# Altium schematic sheet 4_Supply.SchDoc
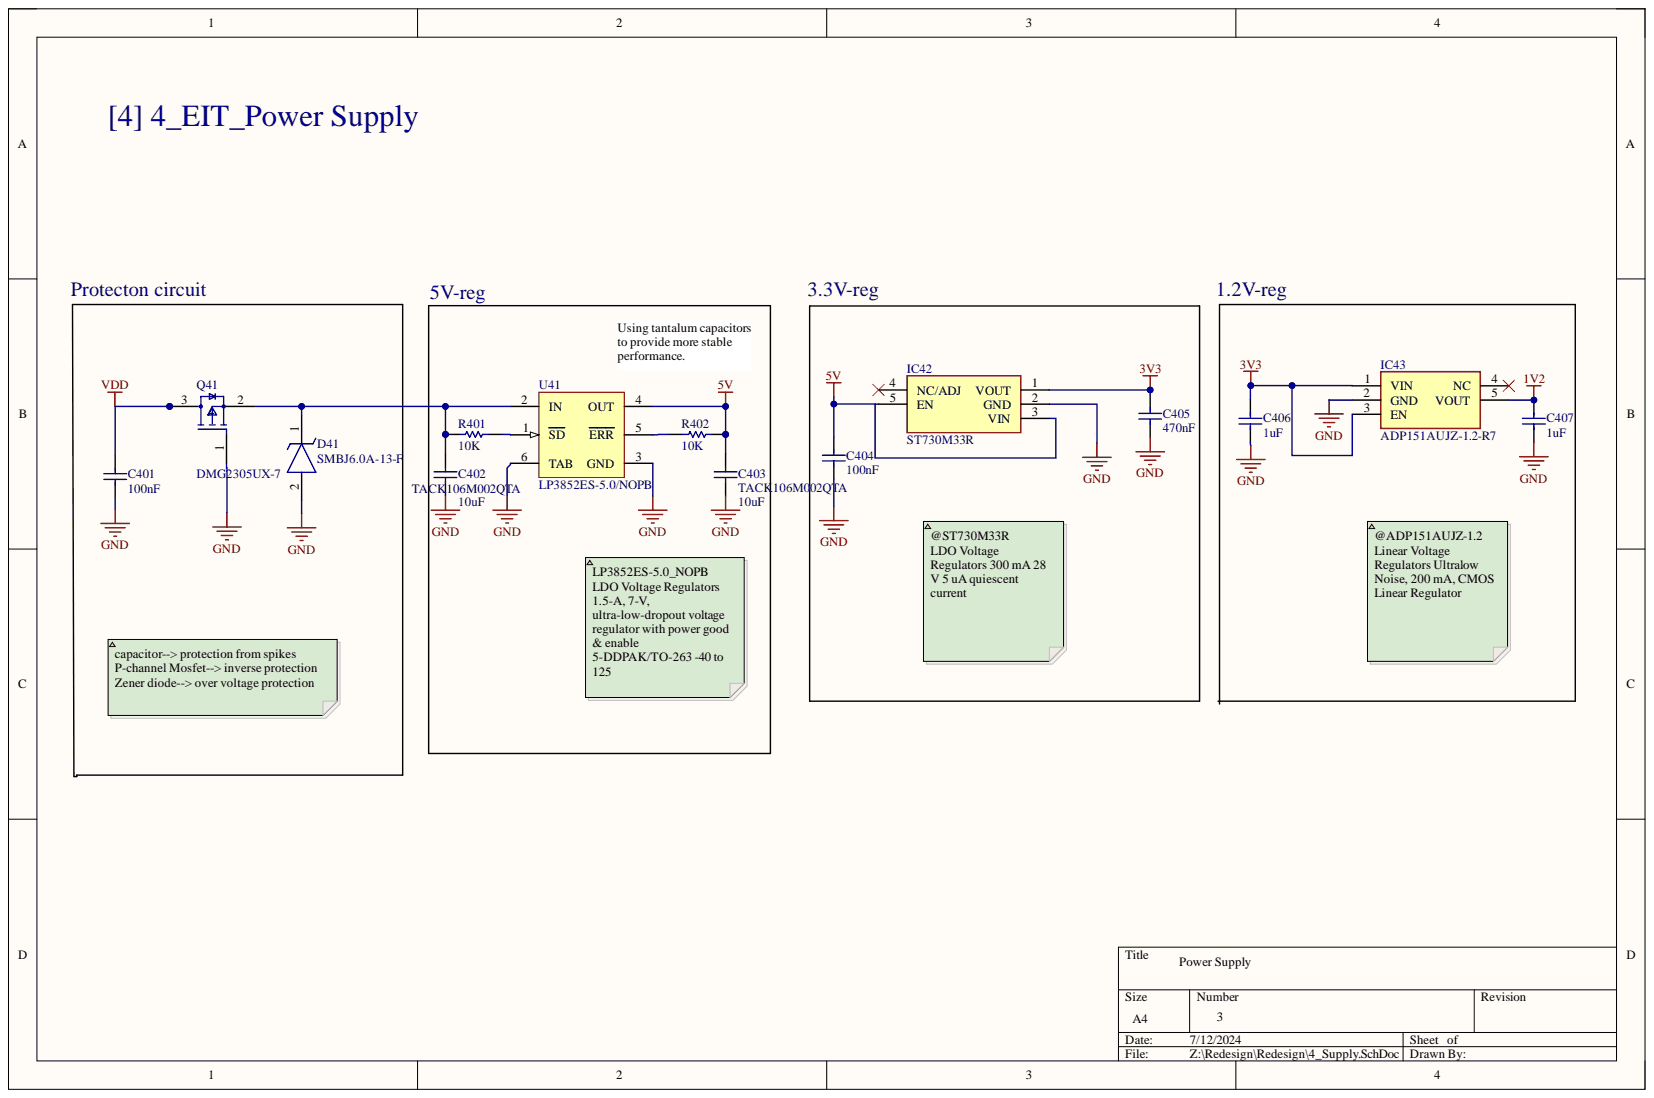
[diagram p0: 4_Supply.SchDoc]

## Parts
C401  value 100nF  [CAPC1005X55X30LL05T10]  (hidden comment: generic)
C402  TACK106M002QTA  Capacitance Const: 10uF  [CAPC1005X70X10LL20T00]
C403  TACK106M002QTA  Capacitance Const: 10uF  [CAPC1005X70X10LL20T00]
C404  value 100nF  [CAPC1005X55X30LL05T10]  (hidden comment: generic)
C405  value 470nF  [CAPC1005X55X30LL05T10]  (hidden comment: generic)
C406  value 1uF  [CAPC1005X55X30LL05T10]  (hidden comment: generic)
C407  value 1uF  [CAPC1005X55X30LL05T10]  (hidden comment: generic)
D41  SMBJ6.0A-13-F  [SMBJ12A]
IC42  ST730M33R  [SOT95P280X145-5N]
IC43  ADP151AUJZ-1.2-R7  [ADI-UJ-5_L]
Q41  DMG2305UX-7  [FP-SOT23-IPC_B]
R401  10K  [RESC1005X40X25LL10T10]
R402  10K  [RESC1005X40X25LL10T10]
U41  LP3852ES-5.0/NOPB  [KTT0005B_N]

## Nets
1V2: C407.1 IC43.5(VOUT)
3V3: C405.1 C406.1 IC42.1(VOUT) IC43.1(VIN) IC43.3(EN)
5V: C403.2 C404.1 IC42.3(VIN) IC42.5(EN) R402.1 U41.4(OUT)
GND: C401.2 C402.1 C403.1 C404.2 C405.2 C406.2 C407.2 D41.2 IC42.2(GND) IC43.2(GND) Q41.1 U41.3(GND) U41.6(TAB)
VDD: C401.1 Q41.3
NetC402_2: C402.2 D41.1 Q41.2 R401.2 U41.2(IN)
NetR401_1: R401.1 U41.1(SD)
NetR402_2: R402.2 U41.5(ERR)

Not connected: IC42 4(NC/ADJ); IC43 4(NC)

## Library data of the parts
C401 C404-C407 = Capacitor: Component Kind: Standard; Description: generic; Device Class L1: Passive Components; Device Class L2: Capacitors; Library Name: RPI_EIT_SchLib.SchLib
C402 C403 = CAP: Manufacturer: AVX Interconnect / Elco; Component Kind: Standard; Part Number: TACK106M002QTA; Library Name: RPI_EIT_SchLib.SchLib
D41 = SMBJ6.0A-13-F: TVS Diode (Uni-directional); Manufacturer_Name: Diodes Incorporated; Arrow Part Number: SMBJ6.0A-13-F; Arrow Price/Stock: https://www.arrow.com/en/products/smbj6.0a-13-f/diodes-incorporated?region=nac; Component Kind: Standard; Datasheet Link: https://www.mouser.com/datasheet/2/115/ds19002-1078141.pdf; Height: 2.5mm; Manufacturer_Part_Number: SMBJ6.0A-13-F; Mouser Part Number: 621-SMBJ6.0A-13-F; Mouser Price/Stock: https://www.mouser.co.uk/ProductDetail/Diodes-Incorporated/SMBJ60A-13-F?qs=gaDBXWSqsDAIOdP0T6ZIBg%3D%3D; Library Name: RPI_EIT_SchLib.SchLib
IC42 = ST730M33R: Integrated Circuit; Manufacturer_Name: STMicroelectronics; Arrow Part Number: ST730M33R; Arrow Price/Stock: https://www.arrow.com/en/products/st730m33r/stmicroelectronics?region=nac; Component Kind: Standard; Datasheet Link: https://www.mouser.at/datasheet/2/389/st730-1621297.pdf; Height: 1.45mm; Manufacturer_Part_Number: ST730M33R; Mouser Part Number: 511-ST730M33R; Mouser Price/Stock: https://www.mouser.co.uk/ProductDetail/STMicroelectronics/ST730M33R?qs=GedFDFLaBXHUqSNzaYM2bg%3D%3D; Library Name: RPI_EIT_SchLib.SchLib
IC43 = ADP151AUJZ-1.2-R7: Integrated Circuit; Manufacturer_Name: Analog Devices; Arrow Part Number: ADP151AUJZ-1.2-R7; Arrow Price/Stock: https://www.arrow.com/en/products/adp151aujz-1.2-r7/analog-devices?region=nac; Component Kind: Standard; Datasheet Link: https://componentsearchengine.com/Datasheets/2/ADP151AUJZ-1.2-R7.pdf; Height: 1mm; Manufacturer_Part_Number: ADP151AUJZ-1.2-R7; Mouser Part Number: 584-ADP151AUJZ-1.2R7; Mouser Price/Stock: https://www.mouser.co.uk/ProductDetail/Analog-Devices/ADP151AUJZ-1.2-R7?qs=WIvQP4zGanhSFSaaw6omsw%3D%3D; Library Name: RPI_EIT_SchLib.SchLib
Q41 = CMP-1693-00002-4: MOSFET P-CH 20V 4.2A SOT23; Drain to Source Resistance: 200mR; Element Configuration: Single; Fall Time: 34.7ns; Gate to Source Voltage (Vgs): 8V; Input Capacitance: 808pF; Max Power Dissipation: 1.4W; Number of Channels: 1; On-State Resistance: 52MR; Rise Time: 13.7ns; Threshold Voltage: -900mV; Turn-Off Delay Time: 79.3ns; Turn-On Delay Time: 10.8ns; Case/Package: SOT-23; Component Kind: Standard; Contact Plating: Tin; Continuous Drain Current (ID): 4.2A; Drain to Source Voltage (Vdss): 20V; Height: 1.1mm; Lead Free: Lead Free; Length: 3mm; Library Name: Altium Content Vault; Max Operating Temperature: 150°C; Min Operating Temperature: -55°C; Mount: Surface Mount; Number of Pins: 3; Package Quantity: 3000; Packaging: Tape and Reel; Radiation Hardening: No; Rds On Max: 52mR; REACH SVHC: No SVHC; Weight: 0.000282oz; Width: 1.4mm
R401 R402 = Resistor: generic; Manufacturer: Yageo / Phycomp; Part Number: RC0402FR-0747KL; Component Kind: Standard; Library Name: RPI_EIT_SchLib.SchLib
U41 = CMP-0062-00102-3: 1.5A Fast Ultra Low Dropout Linear Regulator, 5-pin TO-263, Pb-Free; Manufacturer: Texas Instruments; CompType: LDO IC; PackageTopMarking: NSUZXYTTE#*LP3852ES*-5.0; PowerWise: N; Code_JEDEC: MO-169-AB; Component Kind: Standard; ComponentLink1Description: Datasheet URL; ComponentLink1URL: http://www.ti.com/general/docs/lit/getliterature.tsp?genericPartNumber=LP3852&fileType=pdf; Library Name: Altium Content Vault; PackageDescription: TO-263, Molded, .400 x .390 x .180in, 5 Lead, .067in Pitch, Surface Mount; PackageReference: KTT0005B; PinCount: 5; RoHS: Y
